# Altium schematic sheet Sheet1.SchDoc(Sheet1)
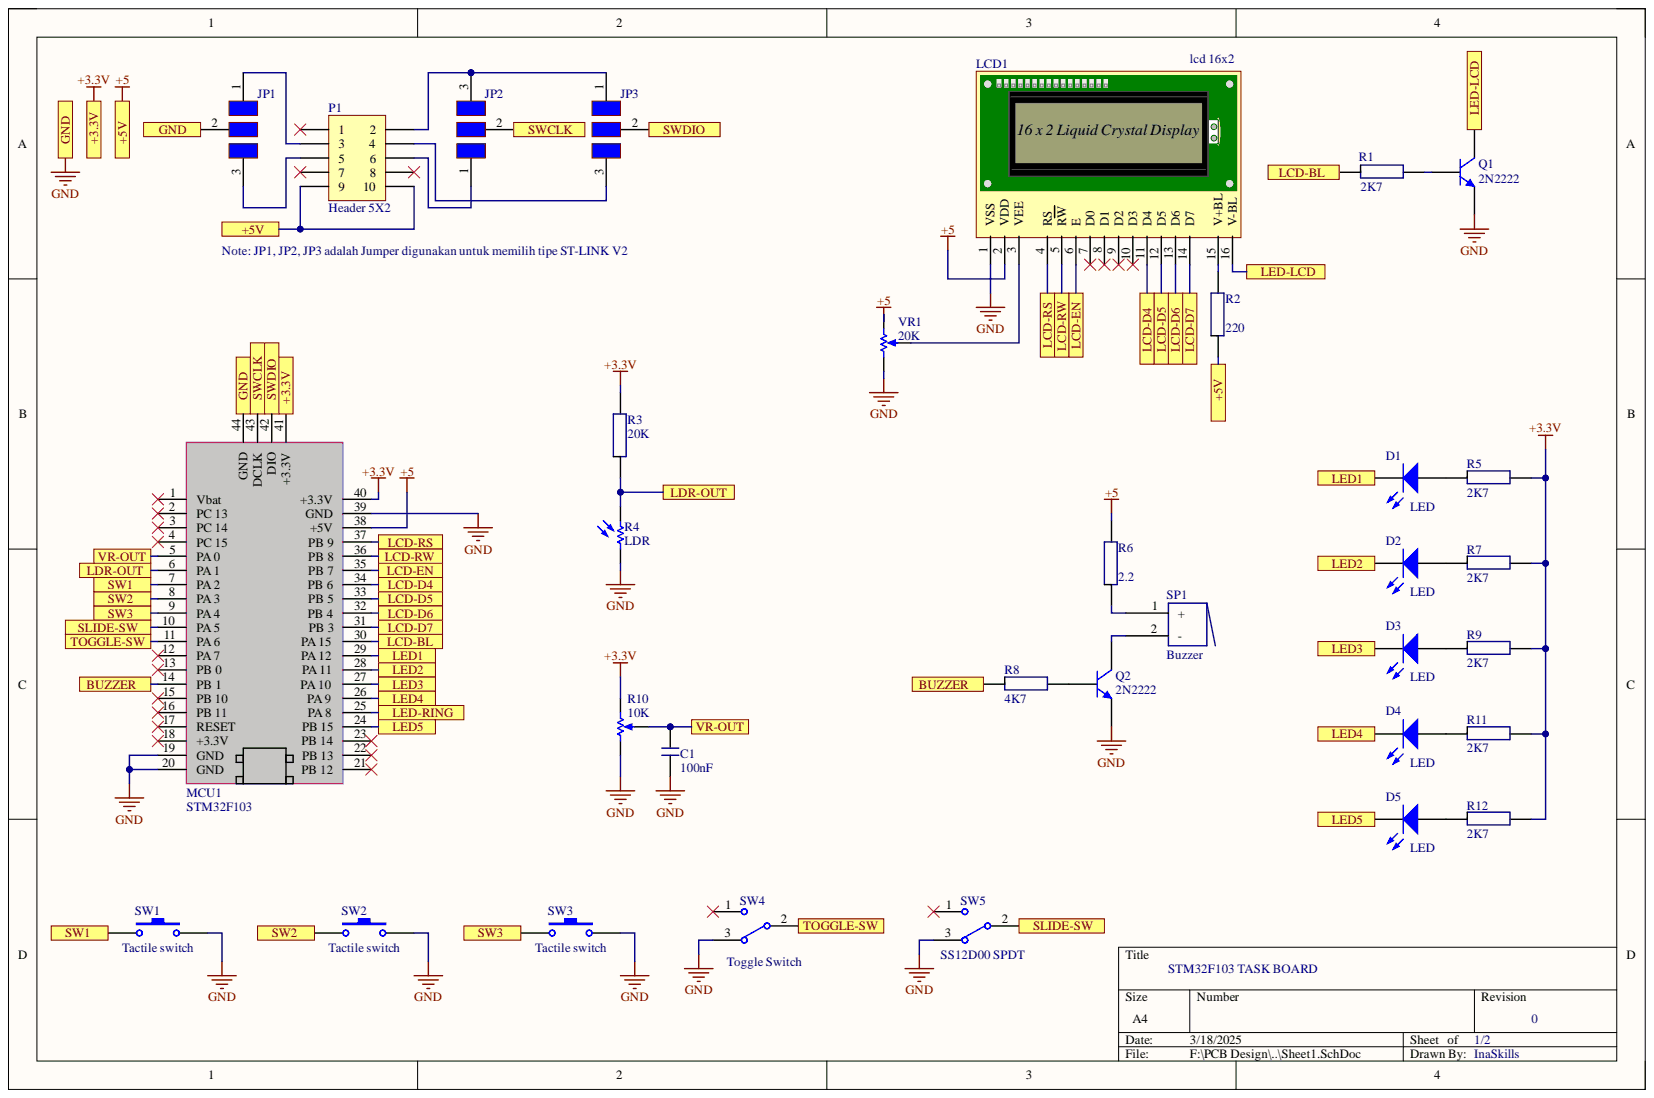
[diagram p0: Sheet1.SchDoc]

## Parts
C1  value 100nF  [0805]  (hidden comment: Capasitor Non Polar)
D1  LED  [LED1206]
D2  LED  [LED1206]
D3  LED  [LED1206]
D4  LED  [LED1206]
D5  LED  [LED1206]
JP1  JP 3 Pin  [JP3P]  (value not drawn)
JP2  JP 3 Pin  [JP3P]  (value not drawn)
JP3  JP 3 Pin  [JP3P]  (value not drawn)
LCD1  lcd 16x2  [lcd 16x2]
MCU1  STM32F103  [STM32F103C8 f]
P1  Header 5X2  [HDR2X5]
Q1  2N2222  [SOT23]
Q2  2N2222  [SOT23]
R1  value 2K7  [0805]  (hidden comment: Resistor)
R2  value 220  [0805]  (hidden comment: Resistor)
R3  value 20K  [0805]  (hidden comment: Resistor)
R4  LDR  [LDR]
R5  value 2K7  [0805]  (hidden comment: Resistor)
R6  value 2.2  [0805]  (hidden comment: Resistor)
R7  value 2K7  [0805]  (hidden comment: Resistor)
R8  value 4K7  [0805]  (hidden comment: Resistor)
R9  value 2K7  [0805]  (hidden comment: Resistor)
R10  value 10K  [VR-knop]  (hidden comment: Potensiometer)
R11  value 2K7  [0805]  (hidden comment: Resistor)
R12  value 2K7  [0805]  (hidden comment: Resistor)
SP1  Buzzer  [buzzer]
SW1  Tactile switch  [tactileSW2p]
SW2  Tactile switch  [tactileSW2p]
SW3  Tactile switch  [tactileSW2p]
SW4  Toggle Switch  [toggle]
SW5  SS12D00 SPDT  [Switch On-OFF]
VR1  value 20K  [single trun]  (hidden comment: Single Turn)

## Ports
+3.3V, +5V, BUZZER, GND, LCD-BL, LCD-D4, LCD-D5, LCD-D6, LCD-D7, LCD-EN, LCD-RS, LCD-RW, LDR-OUT, LED1, LED2, LED3, LED4, LED5, LED-LCD, LED-RING, SLIDE-SW, SW1, SW2, SW3, SWCLK, SWDIO, TOGGLE-SW, VR-OUT

## Nets
+3.3V: MCU1.40(+3.3V) MCU1.41(+3.3V) R3.1 R5.1 R7.1 R9.1 R10.3 R11.1 R12.1 ; port +3.3V
+5: LCD1.2(VDD) MCU1.38(+5V) P1.9 P1.10 R2.2 R6.1 VR1.3 ; port +5V
BUZZER: MCU1.14(PB_1) R8.2 ; port BUZZER
GND: C1.2 JP1.2 LCD1.1(VSS) MCU1.19(GND) MCU1.20(GND) MCU1.39(GND) MCU1.44(GND) Q1.2 Q2.2 R4.1 R10.1 SW1.2 SW2.2 SW3.2 SW4.3 SW5.3 VR1.1 ; port GND
LCD-BL: MCU1.30(PA_15) R1.2 ; port LCD-BL
LCD-D4: LCD1.11(D4) MCU1.34(PB_6) ; port LCD-D4
LCD-D5: LCD1.12(D5) MCU1.33(PB_5) ; port LCD-D5
LCD-D6: LCD1.13(D6) MCU1.32(PB_4) ; port LCD-D6
LCD-D7: LCD1.14(D7) MCU1.31(PB_3) ; port LCD-D7
LCD-EN: LCD1.6(E) MCU1.35(PB_7) ; port LCD-EN
LCD-RS: LCD1.4(RS) MCU1.37(PB_9) ; port LCD-RS
LCD-RW: LCD1.5(RW) MCU1.36(PB_8) ; port LCD-RW
LDR-OUT: MCU1.6(PA_1) R3.2 R4.2 ; port LDR-OUT
LED1: D1.2 MCU1.29(PA_12) ; port LED1
LED2: D2.2 MCU1.28(PA_11) ; port LED2
LED3: D3.2 MCU1.27(PA_10) ; port LED3
LED4: D4.2 MCU1.26(PA_9) ; port LED4
LED5: D5.2 MCU1.24(PB_15) ; port LED5
LED-LCD: LCD1.16(V-BL) Q1.3 ; port LED-LCD
LED-RING: MCU1.25(PA_8) ; port LED-RING
SLIDE-SW: MCU1.10(PA_5) SW5.2 ; port SLIDE-SW
SW1: MCU1.7(PA_2) SW1.1 ; port SW1
SW2: MCU1.8(PA_3) SW2.1 ; port SW2
SW3: MCU1.9(PA_4) SW3.1 ; port SW3
SWCLK: JP2.2 MCU1.43(DCLK) ; port SWCLK
SWDIO: JP3.2 MCU1.42(DIO) ; port SWDIO
TOGGLE-SW: MCU1.11(PA_6) SW4.2 ; port TOGGLE-SW
VR-OUT: C1.1 MCU1.5(PA_0) R10.2 ; port VR-OUT
NetD1_1: D1.1 R5.2
NetD2_1: D2.1 R7.2
NetD3_1: D3.1 R9.2
NetD4_1: D4.1 R11.2
NetD5_1: D5.1 R12.2
NetJP1_1: JP1.1 P1.3
NetJP1_3: JP1.3 P1.5
NetJP2_1: JP2.1 P1.6
NetJP2_3: JP2.3 JP3.1 P1.2
NetJP3_3: JP3.3 P1.4
NetLCD1_3: LCD1.3(VEE) VR1.2
NetLCD1_15: LCD1.15(V+BL) R2.1
NetQ1_1: Q1.1 R1.1
NetQ2_1: Q2.1 R8.1
NetQ2_3: Q2.3 SP1.2
NetR6_2: R6.2 SP1.1

Not connected: LCD1 7(D0) 8(D1) 9(D2) 10(D3); MCU1 1(Vbat) 2(PC_13) 3(PC_14) 4(PC_15) 12(PA_7) 13(PB_0) 15(PB_10) 16(PB_11) 17(RESET) 18(+3.3V) 21(PB_12) 22(PB_13) 23(PB_14); P1 1 7 8; SW4 1; SW5 1

## Library data of the parts
JP1-JP3 = JP3P: Component Kind: Standard; Library Name: faridLib.IntLib
P1 = Header 5X2: Header, 5-Pin, Dual row; Component Kind: Standard; LatestRevisionDate: 17-Jul-2002; LatestRevisionNote: Re-released for DXP Platform.; Library Name: Miscellaneous Connectors.IntLib; Signal Integrity: Connector; Publisher: Altium Limited
Q1 Q2 = NPN SMD: Component Kind: Standard; Library Name: faridLib.IntLib
R10 = VarRes: Component Kind: Standard; Library Name: faridLib.IntLib
SP1 = Buzzer: Value: KLJ-9025-5027SMD; Component Kind: Standard; Library Name: faridLib.IntLib
SW1-SW3 = Tactile switch 2 P: Component Kind: Standard; Library Name: faridLib.IntLib
SW5 = Switch Slide: Component Kind: Standard; Library Name: faridLib.IntLib
VR1 = Singgle Trun: Component Kind: Standard; Library Name: faridLib.IntLib
C1 = Capasitor Non Polar: Component Kind: Standard; Library Name: faridLib.IntLib
D1-D5 = LED: Component Kind: Standard; Library Name: faridLib.IntLib
LCD1 = lcd 16x2: Component Kind: Standard; Library Name: faridLib.IntLib
MCU1 = STM32F103: Component Kind: Standard; Library Name: faridLib.IntLib
R1-R3 R5-R9 R11 R12 = Resistor: Component Kind: Standard; Library Name: faridLib.IntLib
R4 = LDR: Component Kind: Standard; Library Name: faridLib.IntLib
SW4 = Toggle Switch: Component Kind: Standard; Library Name: faridLib.IntLib
